# Altium schematic sheet Schem, Transadmittance Amp_04.SchDoc
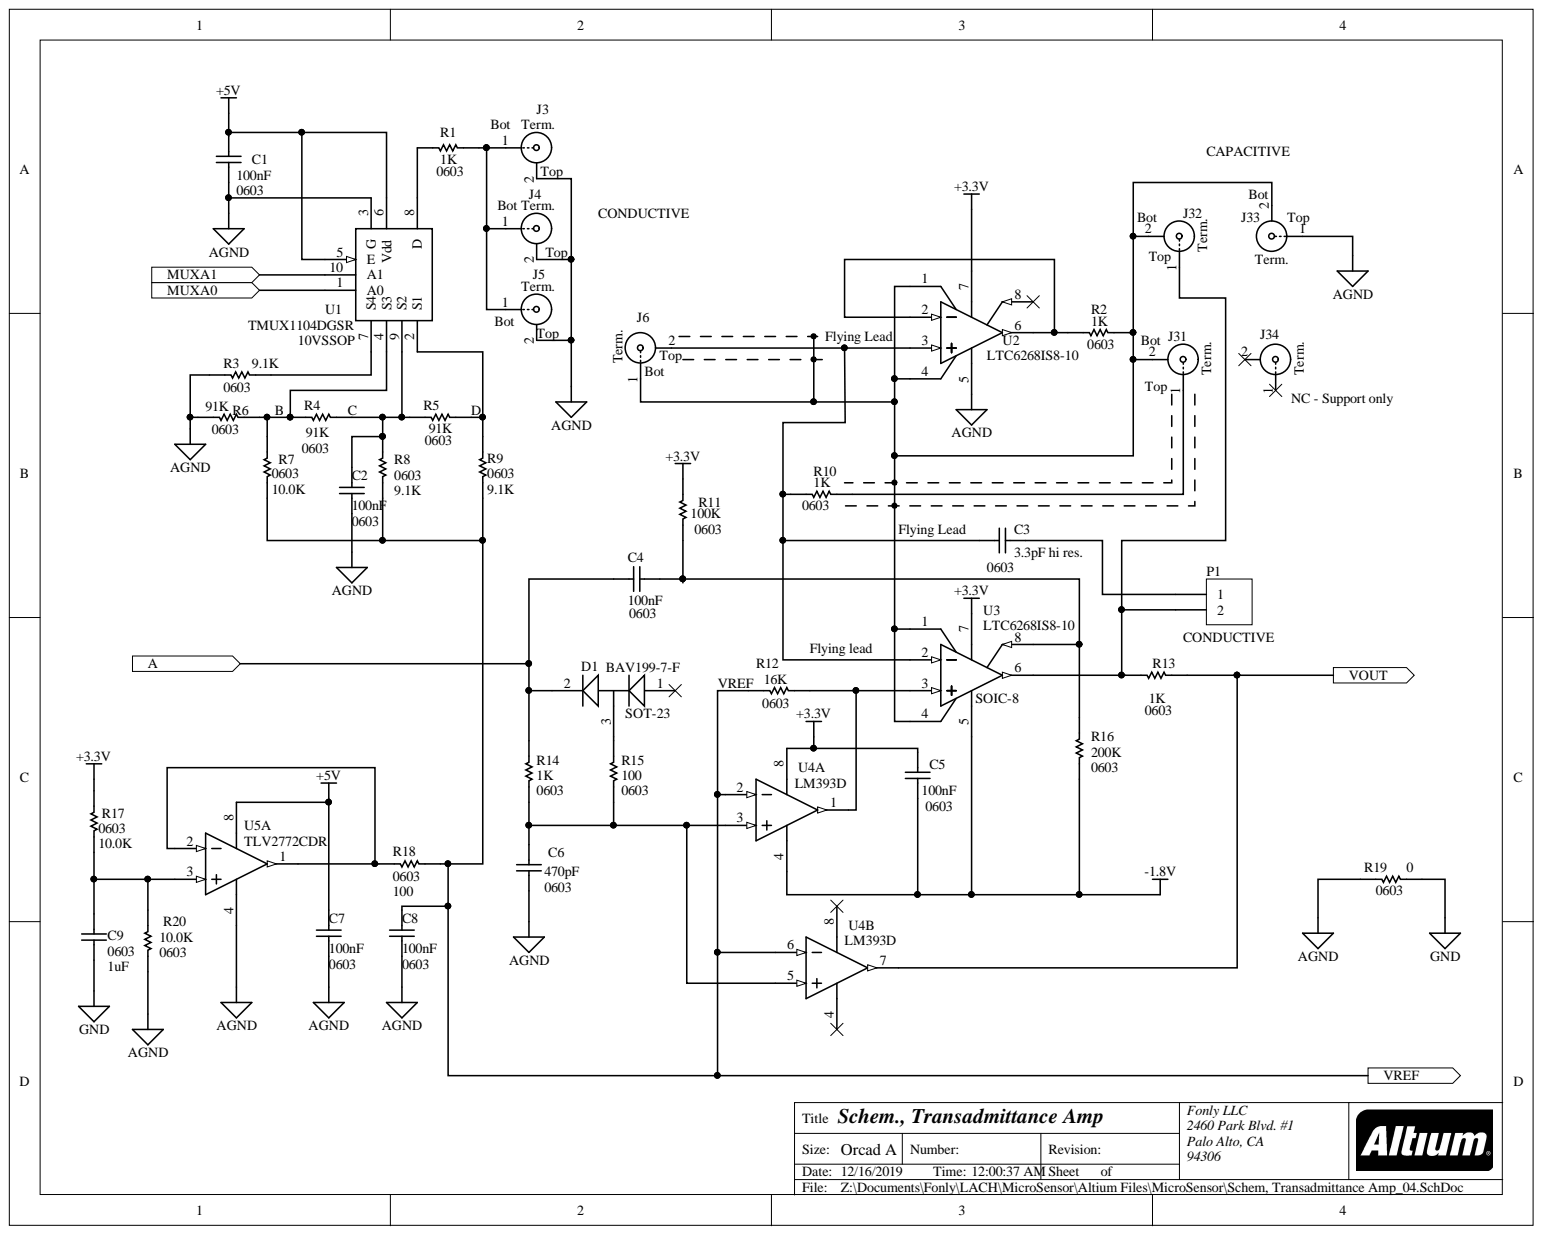
[diagram p0: Schem, Transadmittance Amp_04.SchDoc]

## Parts
C1  0603  value 100nF  [1608[0603]]
C2  0603  value 100nF  [1608[0603]]
C3  0603  value 3.3pF hi res.  [1608[0603]]
C4  0603  value 100nF  [1608[0603]]
C5  0603  value 100nF  [1608[0603]]
C6  0603  value 470pF  [1608[0603]]
C7  0603  value 100nF  [1608[0603]]
C8  0603  value 100nF  [1608[0603]]
C9  0603  value 1uF  [1608[0603]]
D1  BAV199-7-F  Package / Case: SOT-23  [DIOD-SOT23-3_A_V]
J3  Coax endpoint  [Coaxial Terminal]  (value not drawn)
J4  Coax endpoint  [Coaxial Terminal]  (value not drawn)
J5  Coax endpoint  [Coaxial Terminal]  (value not drawn)
J6  Coax endpoint  [Coaxial Terminal]  (value not drawn)
J31  Coax endpoint  [Coaxial Terminal]  (value not drawn)
J32  Coax endpoint  [Coaxial Terminal]  (value not drawn)
J33  Coax endpoint  [Coaxial Terminal]  (value not drawn)
J34  NC - Support only  [Coaxial Terminal]
P1  CONDUCTIVE  [HDR1X2]
R1  0603  value 1K  [RESC1608L]
R2  0603  value 1K  [RESC1608L]
R3  0603  value 9.1K  [RESC1608L]
R4  0603  value 91K  [RESC1608L]
R5  0603  value 91K  [RESC1608L]
R6  0603  value 91K  [RESC1608L]
R7  0603  value 10.0K  [RESC1608L]
R8  0603  value 9.1K  [RESC1608L]
R9  0603  value 9.1K  [RESC1608L]
R10  0603  value 1K  [RESC1608L]
R11  0603  value 100K  [RESC1608L]
R12  0603  value 16K  [RESC1608L]
R13  0603  value 1K  [RESC1608L]
R14  0603  value 1K  [RESC1608L]
R15  0603  value 100  [RESC1608L]
R16  0603  value 200K  [RESC1608L]
R17  0603  value 10.0K  [RESC1608L]
R18  0603  value 100  [RESC1608L]
R19  0603  value 0  [RESC1608L]
R20  0603  value 10.0K  [RESC1608L]
U1  10VSSOP  [10VSSOP]
U2  MFG_P/N: LTC6268IS8-10  [M08A_M]  (hidden comment: SOIC-8)
U3  SOIC-8  MFG_P/N: LTC6268IS8-10  [M08A_M]
U4  LM393D  [D0008A_M]  (parts A,B)
U5  TLV2772CDR  [D0008A_N]  (part A)

## Ports
A, MUXA0, MUXA1, VOUT, VREF

## Nets
+3.3V: C5.1 R11.2 R17.1 U2.7 U3.7 U4.8
+5V: C1.1 C7.1 U1.5(E) U1.6(Vdd) U5.8
-1.8V: C5.2 R16.1 U3.5 U4.4
AGND: C1.2 C2.2 C6.2 C7.2 C8.2 J3.2 J4.2 J5.2 J33.1 R3.2 R6.2 R19.1 R20.2 U1.3(G) U2.5 U5.4
GND: C9.2 R19.2
A: C4.1 D1.2 R14.1 ; port A
B: R4.2 R6.1 R7.2 U1.4(S3)
D: R5.1 R9.1 U1.2(S1)
MUXA0: U1.1(A0) ; port MUXA0
MUXA1: U1.10(A1) ; port MUXA1
VOUT: R13.2 U4.7 ; port VOUT
VREF: C8.1 R7.1 R8.2 R9.2 R12.2 R18.1 U4.2 U4.6 ; port VREF
NetC2_1: C2.1 R4.1 R5.2 R8.1 U1.9(S2)
NetC3_1: C3.1 J6.2 R10.1 U2.3 U3.2
NetC3_2: C3.2 P1.1
NetC4_2: C4.2 R11.1 R16.2 U3.8
NetC6_1: C6.1 R14.2 R15.2 U4.3 U4.5
NetC9_1: C9.1 R17.2 R20.1 U5.3
NetD1_3: D1.3 R15.1
NetJ3_1: J3.1 J4.1 J5.1 R1.1
NetJ6_1: J6.1 J31.2 J32.2 J33.2 R2.2 U2.1 U2.4 U3.1 U3.4
NetJ31_1: J31.1 R10.2
NetJ32_1: J32.1 P1.2 R13.1 U3.6
NetR1_2: R1.2 U1.8(D)
NetR2_1: R2.1 U2.2 U2.6
NetR3_1: R3.1 U1.7(S4)
NetR12_1: R12.1 U3.3 U4.1
NetR18_2: R18.2 U5.1 U5.2
C:

Not connected: D1 1; J34 1 2; U2 8

## Library data of the parts
C1 C2 C4-C8 = Cap Semi: Capacitor Ceramic, SMD, 0603, 0.1uF, 16V, 10%; MFG: Samsung; MFG_P/N: CL10B104KO8NNWC; Vendor: DigiKey; Vendor P/N: 1276-1939-1-ND; Component Kind: Standard; Library Name: Miscellaneous Devices.SchLib; Signal Integrity: Cap Semi; Simulation: CAP
C3 = Cap Semi: Cap., ceramic, ultra-lo ESR, 3.3pF 10^12 ohm; MFG: AVX; MFG_P/N: MK03V73R3BAT2A; Vendor: DigiKey; Vendor P/N: 478-6283-1-ND; Component Kind: Standard; Library Name: Miscellaneous Devices.SchLib; Signal Integrity: Cap Semi; Simulation: CAP
C9 = Cap Semi: Capacitor Ceramic, SMD, 0603, .01uF, 16V, 10%; MFG: Kemet; MFG_P/N: C0603C105M3RACTU; Vendor: DigiKey; Vendor P/N: 399-7377-1-ND; Component Kind: Standard; Library Name: Miscellaneous Devices.SchLib; Signal Integrity: Cap Semi; Simulation: CAP
D1 = CMP-2000-05931-1: Dual Surface Mount Low Leakage Diode, 1.25 V, -65 to 150 degC, 3-Pin SOT23, RoHS, Tape and Reel; MFG: DiodesIncorporated; Vendor: DigiKey; Vendor_P/N: BAV199-FDICT-ND; Component Kind: Standard; Library Name: Altium Content Vault; MFG_P/N: BAV199-7-F; Package Reference: SOT23-3; Series: BAV199
J3-J6 J31-J34 = Coaxial Term: Coaxial pattern for 375mil outer spacers, connected (M-F) standoff ctr.; Component Kind: Standard; Library Name: Current Miscellaneous Connectors.SchLib
P1 = Header 2: Header, 2-Pin, M, 0.1"; MFG: TE; MFG_P/N: 87224-2; Vendor: DigiKey; Vendor_P/N: A26543-ND; Component Kind: Standard; LatestRevisionDate: 17-Jul-2002; LatestRevisionNote: Re-released for DXP Platform.; Library Name: Current Miscellaneous Connectors.SchLib; Signal Integrity: Connector; Publisher: Altium Limited
R1 = Res1: Resistor; MFG: Panasonic; MFG_P/N: ERJ-3EKF1001V; Vendor: DigiKey; Vendor P/N: P1.00KHCT-ND; Component Kind: Standard; Library Name: Miscellaneous Devices.SchLib; Signal Integrity: Res; Simulation: RESISTOR
R2 R10 R13 = Res1: Resistor; MFG: Panasonic; MFG_P/N: ERJ-3EKF1000V; Vendor: DigiKey; Vendor P/N: P100HCT-ND; Component Kind: Standard; Library Name: Miscellaneous Devices.SchLib; Signal Integrity: Res; Simulation: RESISTOR
R3 = Res1: Resistor; MFG: Panasonic; MFG_P/N: ERJ-3EKF9101V; Vendor: DigiKey; Vendor P/N: P91.0KHCT-ND; Component Kind: Standard; Library Name: Miscellaneous Devices.SchLib; Signal Integrity: Res; Simulation: RESISTOR
R4-R6 R11 R12 R14-R16 = Res1: Resistor; MFG: Panasonic; MFG_P/N: ERJ-3EKF9102V; Vendor: DigiKey; Vendor P/N: P91.0KHCT-ND; Component Kind: Standard; Library Name: Miscellaneous Devices.SchLib; Signal Integrity: Res; Simulation: RESISTOR
R7 R17 = Res1: Resistor; MFG: Panasonic; MFG_P/N: ERJ-3EKF1002V; Vendor: DigiKey; Vendor P/N: P10.0KHCT-ND; Component Kind: Standard; Library Name: Miscellaneous Devices.SchLib; Signal Integrity: Res; Simulation: RESISTOR
R8 R9 = Res1: Resistor; MFG: Panasonic; MFG_P/N: ERJ-3EKF9101V; Vendor: DigiKey; Vendor P/N: P9.10KHCT-ND; Component Kind: Standard; Library Name: Miscellaneous Devices.SchLib; Signal Integrity: Res; Simulation: RESISTOR
R18 = Res1: Resistor; MFG: Panasonic; MFG_P/N: ERJ-3EKF1000V; Value: 100; Vendor: DigiKey; Vendor P/N: P100HCT-ND; Component Kind: Standard; Library Name: Miscellaneous Devices.SchLib; Signal Integrity: Res; Simulation: RESISTOR
R19 = Res1: Resistor; MFG: Panasonic; MFG_P/N: ERJ-3GEY0R00V; Vendor: DigiKey; Vendor P/N: ERJ-3EKF49R9V; Component Kind: Standard; Library Name: Miscellaneous Devices.SchLib; Signal Integrity: Res; Simulation: RESISTOR
R20 = Res1: Resistor; MFG: Panasonic; MFG_P/N: ERJ-3EKF2002V; Vendor: DigiKey; Vendor P/N: P20.0KHCT-ND; Component Kind: Standard; Library Name: Miscellaneous Devices.SchLib; Signal Integrity: Res; Simulation: RESISTOR
U1 = TMUX1104DGSR: Analog Mux 4:1 low leakage; MFG: TI; Vendor: Mouset; Vendor P/N: 595-TMUX1104DGSR; Component Kind: Standard; Library Name: TI Logic Switch.SchLib; MFG_P/N: TMUX1104DGSR
U2 U3 = LTC6268-10: Op Amp, low input current; MFG: LTC/Analog; Vendor: DigiKey; Vendor P/N: LTC6268IS8-10#PBF-ND; Component Kind: Standard; Library Name: LT Operational Amplifier.SchLib
U4 = CMP-2000-04951-1: Dual Differential Comparator, 2 to 30 V, 0 to 70 degC, 8-pin SOIC (D8), Green (RoHS & no Sb/Br); Manufacturer: TexasInstruments; Maximum Dual Supply Voltage: ±18V; Maximum Single Supply Voltage: 36V; Minimum Dual Supply Voltage: ±1V; Minimum Single Supply Voltage: 2V; Number of Channels per Chip: 2; Output Type: OpenCollector; Typical Response Time: 1.3us; Typical Voltage Gain: 106.02dB; Category: Comparator; Component Kind: Standard; Datasheet URL: https://datasheet.ciiva.com/15131/getdatasheetpartid-248499-15131100.pdf; Datasheet Version: Rev. W, 07/2010; Library Name: Altium Content Vault; Manufacturer URL: http://www.ti.com/; Mounting: SurfaceMount; Operating Temperature: 0to70degC; Package: 8SOIC; Package Description: 8-Pin Small Outline Integrated Circuit, Body 4.9 x 3.9 mm, Pitch 1.27 mm; Package Reference: D8; Package URL: http://www.ti.com/lit/ml/msoi002j/msoi002j.pdf; Packaging: Rail/Tube; Rad Hard: No; Rail to Rail: No; Strobe Capability: No
U5 = CMP-0272-03014-3: Dual High-Slew-Rate Rail-to-Rail Output Operational Amplifier, 2.5 to 6 V, 0 to 70 degC, 8-pin SOIC (D8), Green (RoHS & no Sb/Br); MFG: TI; Vendor: DigiKey; Vendor P/N: 296-10677-1-ND; Component Kind: Standard; ComponentLink1Description: Manufacturer URL; ComponentLink1URL: http://www.ti.com/; ComponentLink2Description: Datasheet; ComponentLink2URL: http://www.ti.com/lit/ds/symlink/tlv2772.pdf; ComponentLink3Description: Package Specification; ComponentLink3URL: http://www.ti.com/litv/pdf/msoi002j; Library Name: Altium Content Vault; MFG_P/N: TLV2772CDR
